# Altium schematic sheet BOARD_POWER2.SchDoc
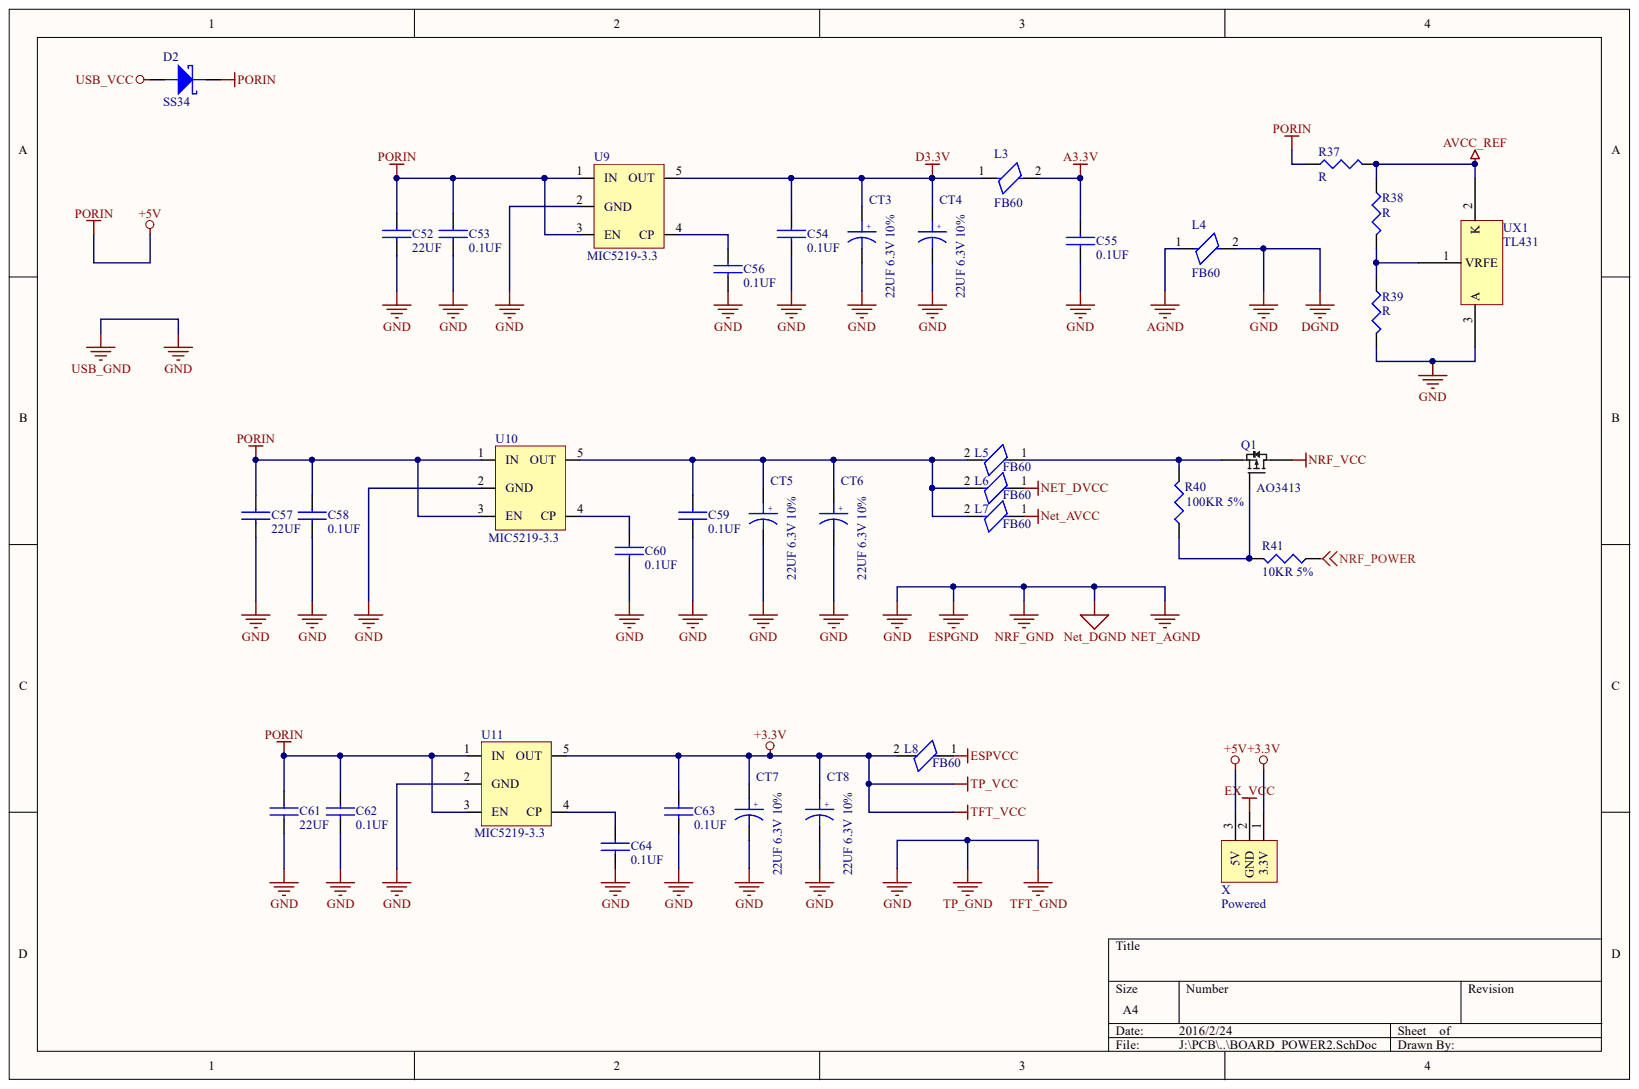
[diagram p0: BOARD_POWER2.SchDoc]

## Parts
C52  22UF  [CAPC2013X120N]
C53  0.1UF  [CAPC1005X05N]
C54  0.1UF  [CAPC1005X05N]
C55  0.1UF  [CAPC1005X05N]
C56  0.1UF  [CAPC1005X05N]
C57  22UF  [CAPC2013X120N]
C58  0.1UF  [CAPC1005X05N]
C59  0.1UF  [CAPC1005X05N]
C60  0.1UF  [CAPC1005X05N]
C61  22UF  [CAPC2013X120N]
C62  0.1UF  [CAPC1005X05N]
C63  0.1UF  [CAPC1005X05N]
C64  0.1UF  [CAPC1005X05N]
CT3  22UF 6.3V 10%  [CAPMP3216X180]
CT4  22UF 6.3V 10%  [CAPMP3216X180]
CT5  22UF 6.3V 10%  [CAPMP3216X180]
CT6  22UF 6.3V 10%  [CAPMP3216X180]
CT7  22UF 6.3V 10%  [CAPMP3216X180]
CT8  22UF 6.3V 10%  [CAPMP3216X180]
D2  SS34  [SOD123_370X125-2N]
L3  FB60  [R0603]
L4  FB60  [R0603]
L5  FB60  [R0603]
L6  FB60  [R0603]
L7  FB60  [R0603]
L8  FB60  [R0603]
Q1  AO3413  [SOT23-3_95P278X120-3N]
R37  R  [RESC1005X04N]
R38  R  [RESC1005X04N]
R39  R  [RESC1005X04N]
R40  100KR 5%  [RESC1005X04N]
R41  10KR 5%  [RESC1005X04N]
U9  MIC5219-3.3  [SOT95P280X145-5N]
U10  MIC5219-3.3  [SOT95P280X145-5N]
U11  MIC5219-3.3  [SOT95P280X145-5N]
UX1  TL431  [SOT23-3_95P240X100-3N]
X  Powered  [Header 3X1]

## Nets
+3.3V: C63.1 CT7.1 CT8.1 L8.2 U11.5(OUT) X.1
+5V: C52.1 C53.1 C57.1 C58.1 C61.1 C62.1 D2.K R37.1 U9.1(IN) U9.3(EN) U10.1(IN) U10.3(EN) U11.1(IN) U11.3(EN) X.3
A3.3V: C55.1 L3.2
AGND: L4.1
AVCC_REF: R37.2 R38.1 UX1.2
D3.3V: C54.1 CT3.1 CT4.1 L3.1 U9.5(OUT)
DGND: C52.2 C53.2 C54.2 C55.2 C56.2 C57.2 C58.2 C59.2 C60.2 C61.2 C62.2 C63.2 C64.2 CT3.2 CT4.2 CT5.2 CT6.2 CT7.2 CT8.2 L4.2 R39.2 U9.2(GND) U10.2(GND) U11.2(GND) UX1.3
ESPVCC: L8.1
EX_VCC: X.2
NET_AVCC: L7.1
NET_DVCC: L6.1
NRF_POWER: R41.1
NRF_VCC: Q1.3
USB_VCC: D2.A
NetC56_1: C56.1 U9.4(CP)
NetC59_1: C59.1 CT5.1 CT6.1 L5.2 L6.2 L7.2 U10.5(OUT)
NetC60_1: C60.1 U10.4(CP)
NetC64_1: C64.1 U11.4(CP)
NetL5_1: L5.1 Q1.2 R40.2
NetQ1_1: Q1.1 R40.1 R41.2
NetR38_2: R38.2 R39.1 UX1.1

## Library data of the parts
C52-C64 = CAP: Capacitor nonpolarity; Component Kind: Standard; Library Name: RCL_Standard.SchLib
CT3-CT8 = CAPACITOR POL: Capacitor polarity; Component Kind: Standard; Library Name: RCL_Standard.SchLib
D2 = DIODE SCHOTTKY: Diode schottky (肖特基),SS34..; Component Kind: Standard; Library Name: RCL_Standard.SchLib
L3-L8 = BEAD_ FERRITE: Ferrite bead; Component Kind: Standard; Library Name: RCL_Standard.SchLib
Q1 = AO3413: P 沟道增强型 MOSFET; Component Kind: Standard; Library Name: Transistor.SchLib
R37-R41 = R: General Resistors; Component Kind: Standard; Library Name: RCL_Standard.SchLib
U9-U11 = MIC5219: Power IC,LDO,500mA,Low-dropout voltage; Component Kind: Standard; Library Name: IC.SchLib
UX1 = TL431: 电压基准; Component Kind: Standard; Library Name: IC.SchLib
X = Powered: Component Kind: Standard; Library Name: Connector.SchLib
